# Altium schematic sheet MCU_LORA.SchDoc(MCU_LORA)
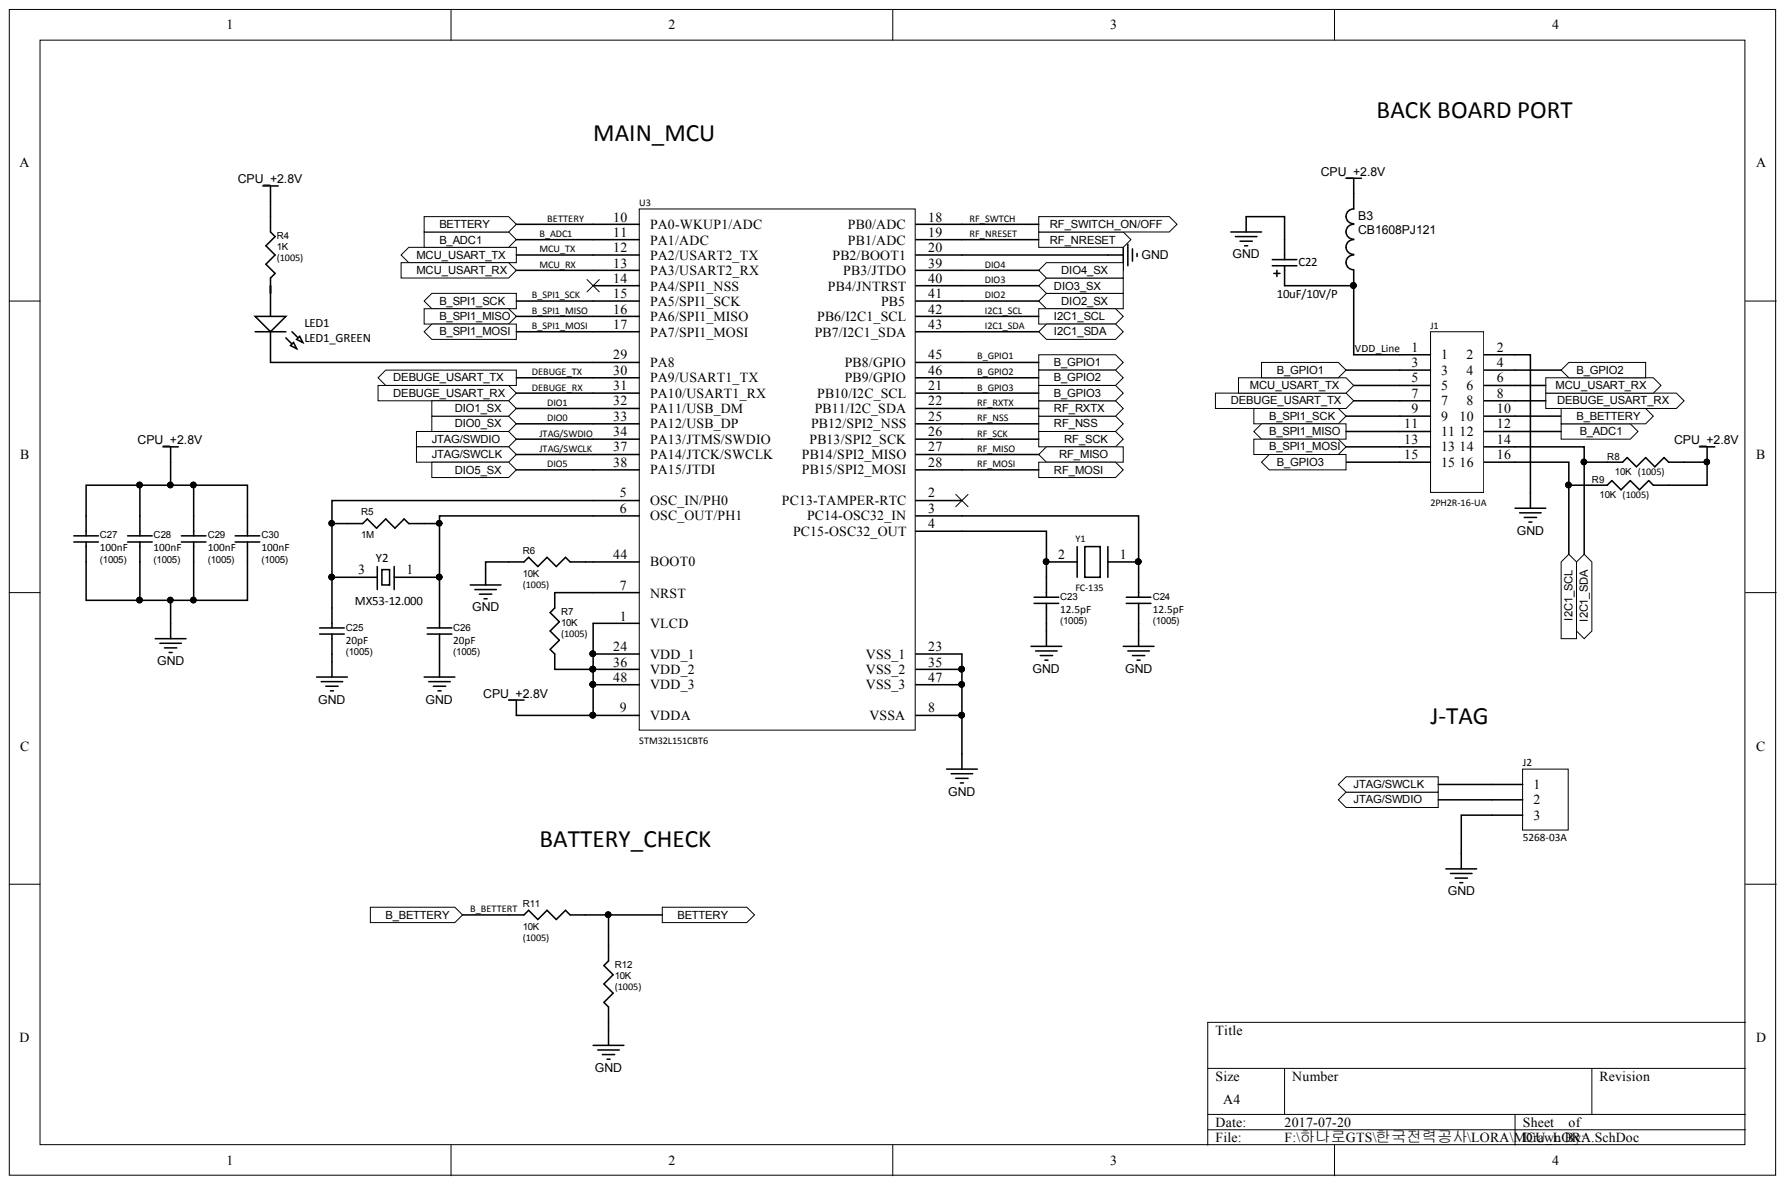
[diagram p0: MCU_LORA.SchDoc]

## Parts
B3  CB1608PJ121  [INDC1608L]
C22  10uF/10V/P  [TANTAL_P]
C23  12.5pF  value (1005)  [C1005]
C24  12.5pF  value (1005)  [C1005]
C25  20pF  value (1005)  [C1005]
C26  20pF  value (1005)  [C1005]
C27  100nF  value (1005)  [C1005]
C28  100nF  value (1005)  [C1005]
C29  100nF  value (1005)  [C1005]
C30  100nF  value (1005)  [C1005]
J1  2PH2R-16-UA  [2PH2R-16-UA]
J2  5268-03A  [5268-03A]
LED1  LED1_GREEN  [LED]
R4  1K  value (1005)  [R1005]
R5  1M  [R1608]
R6  10K  value (1005)  [R1005]
R7  10K  value (1005)  [R1005]
R8  10K  value (1005)  [R1005]
R9  10K  value (1005)  [R1005]
R11  10K  value (1005)  [R1005]
R12  10K  value (1005)  [R1005]
U3  STM32L151CBT6  [LQFP48_N]
Y1  FC-135  [FC-135]
Y2  MX53-12.000  [MX53-8.000]

## Ports
B_ADC1, B_BETTERY, B_GPIO1, B_GPIO2, B_GPIO3, B_SPI1_MISO, B_SPI1_MOSI, B_SPI1_SCK, BETTERY, DEBUGE_USART_RX, DEBUGE_USART_TX, DIO0_SX, DIO1_SX, DIO2_SX, DIO3_SX, DIO4_SX, DIO5_SX, I2C1_SCL, I2C1_SDA, JTAG/SWCLK, JTAG/SWDIO, MCU_USART_RX, MCU_USART_TX, RF_MISO, RF_MOSI, RF_NRESET, RF_NSS, RF_RXTX, RF_SCK, RF_SWITCH_ON/OFF

## Nets
CPU_+2.8V: B3.2 C27.2 C28.2 C29.2 C30.2 R4.1 R7.1 R8.2 R9.2 U3.1(VLCD) U3.9(VDDA) U3.24(VDD_1) U3.36(VDD_2) U3.48(VDD_3)
GND: C22.2 C23.1 C24.1 C25.1 C26.1 C27.1 C28.1 C29.1 C30.1 J1.2 J2.3 R6.1 R12.1 U3.8(VSSA) U3.20(PB2/BOOT1) U3.23(VSS_1) U3.35(VSS_2) U3.47(VSS_3)
B_ADC1: J1.12 U3.11(PA1/ADC) ; port B_ADC1
B_BETTERT: J1.10 R11.1 ; port B_BETTERY
B_GPIO1: J1.3 U3.45(PB8/GPIO) ; port B_GPIO1
B_GPIO2: J1.4 U3.46(PB9/GPIO) ; port B_GPIO2
B_GPIO3: J1.15 U3.21(PB10/I2C_SCL) ; port B_GPIO3
B_SPI1_MISO: J1.11 U3.16(PA6/SPI1_MISO) ; port B_SPI1_MISO
B_SPI1_MOSI: J1.13 U3.17(PA7/SPI1_MOSI) ; port B_SPI1_MOSI
B_SPI1_SCK: J1.9 U3.15(PA5/SPI1_SCK) ; port B_SPI1_SCK
BETTERY: R11.2 R12.2 U3.10(PA0-WKUP1/ADC) ; port BETTERY
DEBUGE_RX: J1.8 U3.31(PA10/USART1_RX) ; port DEBUGE_USART_RX
DEBUGE_TX: J1.7 U3.30(PA9/USART1_TX) ; port DEBUGE_USART_TX
DIO0: U3.33(PA12/USB_DP) ; port DIO0_SX
DIO1: U3.32(PA11/USB_DM) ; port DIO1_SX
DIO2: U3.41(PB5) ; port DIO2_SX
DIO3: U3.40(PB4/JNTRST) ; port DIO3_SX
DIO4: U3.39(PB3/JTDO) ; port DIO4_SX
DIO5: U3.38(PA15/JTDI) ; port DIO5_SX
I2C1_SCL: J1.16 R9.1 U3.42(PB6/I2C1_SCL) ; port I2C1_SCL
I2C1_SDA: J1.14 R8.1 U3.43(PB7/I2C1_SDA) ; port I2C1_SDA
JTAG/SWCLK: J2.1 U3.37(PA14/JTCK/SWCLK) ; port JTAG/SWCLK
JTAG/SWDIO: J2.2 U3.34(PA13/JTMS/SWDIO) ; port JTAG/SWDIO
MCU_RX: J1.6 U3.13(PA3/USART2_RX) ; port MCU_USART_RX
MCU_TX: J1.5 U3.12(PA2/USART2_TX) ; port MCU_USART_TX
RF_MISO: U3.27(PB14/SPI2_MISO) ; port RF_MISO
RF_MOSI: U3.28(PB15/SPI2_MOSI) ; port RF_MOSI
RF_NRESET: U3.19(PB1/ADC) ; port RF_NRESET
RF_NSS: U3.25(PB12/SPI2_NSS) ; port RF_NSS
RF_RXTX: U3.22(PB11/I2C_SDA) ; port RF_RXTX
RF_SCK: U3.26(PB13/SPI2_SCK) ; port RF_SCK
RF_SWTCH: U3.18(PB0/ADC) ; port RF_SWITCH_ON/OFF
VDD_LINE: B3.1 C22.1 J1.1
NetC23_2: C23.2 U3.4(PC15-OSC32_OUT) Y1.2
NetC24_2: C24.2 U3.3(PC14-OSC32_IN) Y1.1
NetC25_2: C25.2 R5.1 U3.5(OSC_IN/PH0) Y2.3
NetC26_2: C26.2 R5.2 U3.6(OSC_OUT/PH1) Y2.1
NetLED1_1: LED1.1 R4.2
NetLED1_2: LED1.2 U3.29(PA8)
NetR6_2: R6.2 U3.44(BOOT0)
NetR7_2: R7.2 U3.7(NRST)

Not connected: U3 2(PC13-TAMPER-RTC) 14(PA4/SPI1_NSS)

## Library data of the parts
B3 = BEAD: Code_IEC: 1005; Code_JEITA: 0402; Component Kind: Standard; Description: bead; LatestRevisionDate: 29-May-2009; LatestRevisionNote: IPC-7351 Footprint Added.; Library Name: S_BOARD.SchLib; PackageDescription: Chip Inductor; PackageReference: 0402-A; Published: 8-Jun-2000; Signal Integrity: Inductor; Simulation: INDUCTOR; Value: CB1608PJ121; Publisher: Altium Limited
C22 = TANTAL_P: Component Kind: Standard; Description: TANTAL_P; Library Name: S_BOARD.SchLib; Signal Integrity: Cap Pol; Simulation: CAP
C23-C30 = C1005: Capacitor (Semiconductor SIM Model); Component Kind: Standard; LatestRevisionDate: 29-May-2009; LatestRevisionNote: IPC-7351 Footprint Added.; Library Name: S_BOARD.SchLib; PackageDescription: Chip Capacitor; PackageReference: C1206; Published: 8-Jun-2000; Signal Integrity: Cap Semi; Simulation: CAP; Publisher: Altium Limited
J1 = 2PH2R-16-UA: Pin Header 2mm RA; Component Kind: Standard; Library Name: LORA.SCHLIB
J2 = 5268-03A: MOLEX-3PIN_RA; Component Kind: Standard; LatestRevisionDate: 17-Jul-2002; LatestRevisionNote: Re-released for DXP Platform.; Library Name: S_BOARD.SchLib; Signal Integrity: Connector; Publisher: Altium Limited
LED1 = LED2: Typical RED, GREEN, YELLOW, AMBER GaAs LED; Component Kind: Standard; LatestRevisionDate: 17-Jul-2002; LatestRevisionNote: Re-released for DXP Platform.; Library Name: S_BOARD.SchLib; PackageDescription: SM LED; 2 Flat Leads; PackageReference: 3.2X1.6X1.1; PackageVersion: Feb-2002; Published: 8-Jun-2000; Simulation: LED2; Publisher: Altium Limited
R4 R7-R9 R11 R12 = R1005: Component Kind: Standard
  R4 R7 R11 R12: Library Name: S_BOARD.SchLib
  R8 R9: Library Name: LORA.SCHLIB
R5 = R: Component Kind: Standard; Library Name: S_BOARD.SchLib
R6 = R1005: Value: (1005); Component Kind: Standard; Library Name: S_BOARD.SchLib
U3 = STM32F101CBT6: STM32 ARM-based 32-bit MCU with 128 Kbytes Flash, 48-pin LQFP, Industrial Temperature; Component Kind: Standard; ComponentLink1Description: Manufacturer Link; ComponentLink1URL: www.st.com/stm32; ComponentLink2Description: Datasheet; ComponentLink2URL: http://www.st.com/stonline/products/literature/ds/13586.pdf; DatasheetVersion: rev6, Mar-2008; Library Name: ST Microcontroller 32-Bit STM32.IntLib; PackageDescription: 48-Pin Low-Profile Quad Flat Pack, 7 x 7 mm Body, 0.5 mm Pitch (LQFP48); PackageReference: LQFP48; PackageVersion: Mar-2008; Published: 30-May-2008; Publisher: Altium Limited
Y1 = FC-135: kHz RANGE CRYSTAL UNIT; Component Kind: Standard; Library Name: LORA.SCHLIB
Y2 = Xtal: X-tal SMD type MX Series; Component Kind: Standard; Library Name: S_BOARD.SchLib
